# Altium schematic sheet 3_BANK1434conf.SchDoc(3_BANK1434conf)
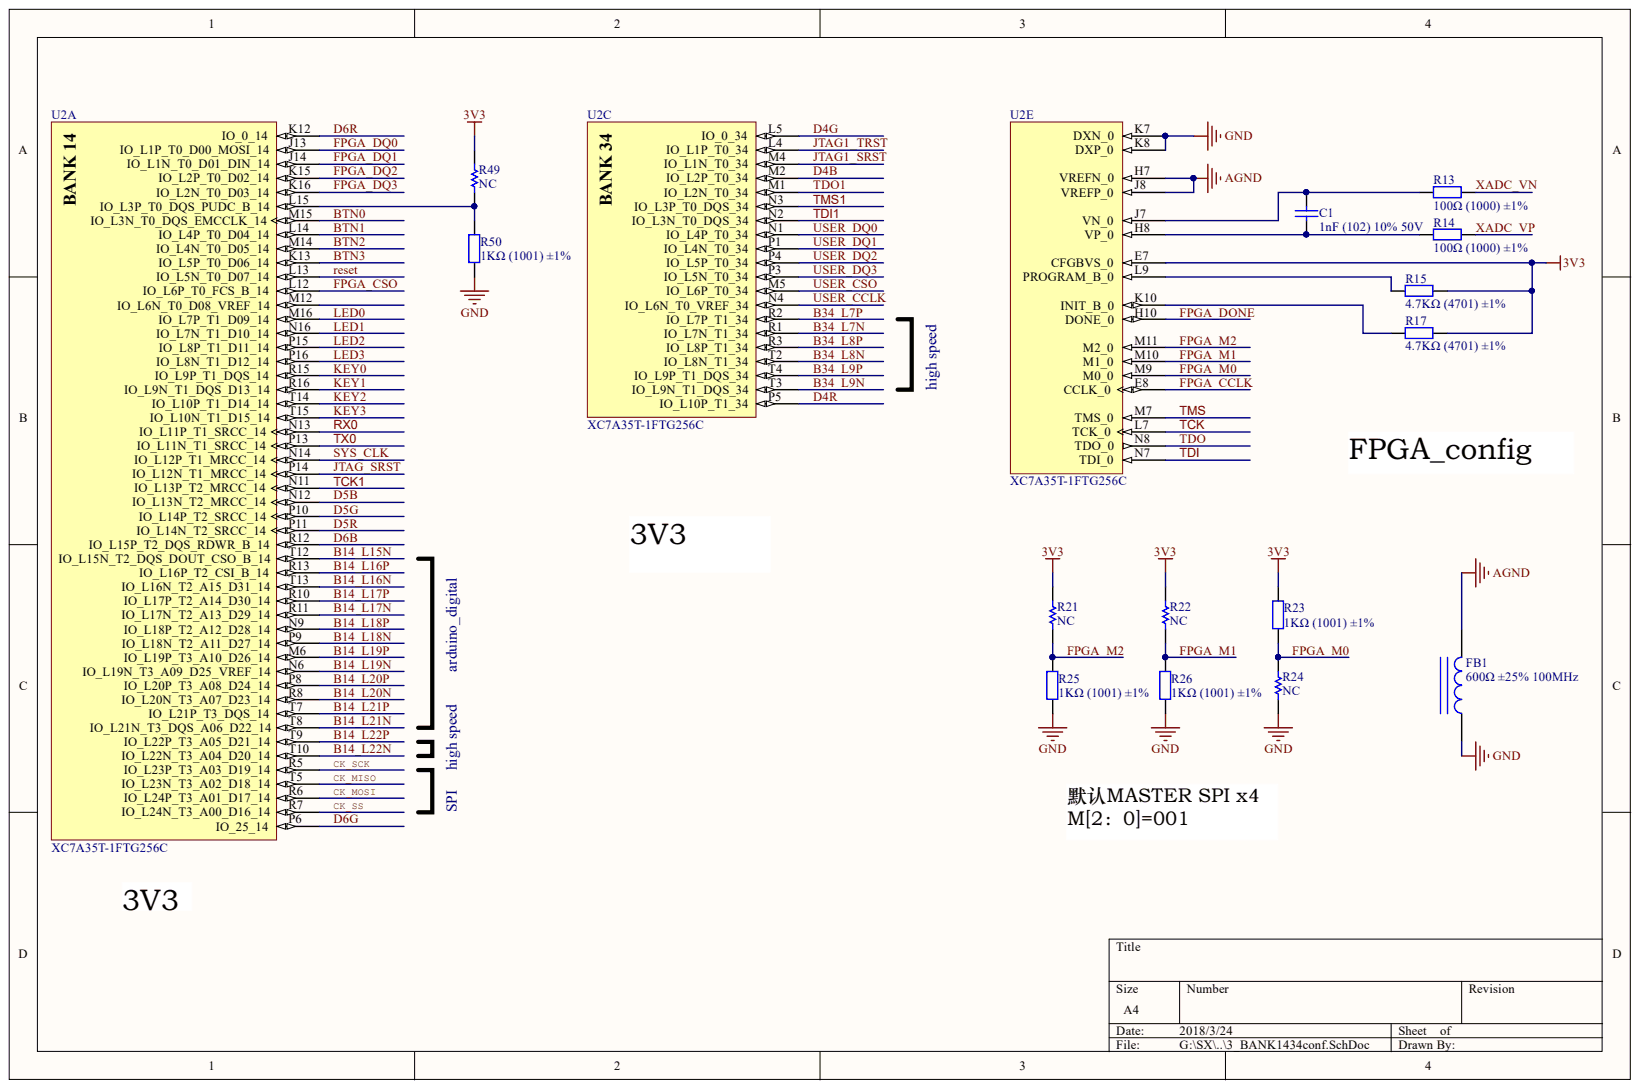
[diagram p0: 3_BANK1434conf.SchDoc]

## Parts
C1  1nF (102) 10% 50V  [C0402_BGA]
FB1  600Ω ±25% 100MHz  [0805_L]
R13  100Ω (1000) ±1%  [R0402_BGA]
R14  100Ω (1000) ±1%  [R0402_BGA]
R15  4.7KΩ (4701) ±1%  [R0402_BGA]
R17  4.7KΩ (4701) ±1%  [R0402_BGA]
R21  NC  [0402_R]
R22  NC  [0402_R]
R23  1KΩ (1001) ±1%  [0402_R]
R24  NC  [0402_R]
R25  1KΩ (1001) ±1%  [0402_R]
R26  1KΩ (1001) ±1%  [0402_R]
R49  NC  [0402_R]
R50  1KΩ (1001) ±1%  [0402_R]
U2  XC7A35T-1FTG256C  [FTG256]  (parts A,C,E)

## Nets
3V3: R15.1 R17.1 R21.2 R22.2 R23.2 R49.2 U2.E7(CFGBVS_0)
AGND: FB1.2 U2.H7(VREFN_0) U2.J8(VREFP_0)
GND: FB1.1 R24.1 R25.1 R26.1 R50.1 U2.K7(DXN_0) U2.K8(DXP_0)
B14_L15N: U2.T12(IO_L15N_T2_DQS_DOUT_CSO_B_14)
B14_L16N: U2.T13(IO_L16N_T2_A15_D31_14)
B14_L16P: U2.R13(IO_L16P_T2_CSI_B_14)
B14_L17N: U2.R11(IO_L17N_T2_A13_D29_14)
B14_L17P: U2.R10(IO_L17P_T2_A14_D30_14)
B14_L18N: U2.P9(IO_L18N_T2_A11_D27_14)
B14_L18P: U2.N9(IO_L18P_T2_A12_D28_14)
B14_L19N: U2.N6(IO_L19N_T3_A09_D25_VREF_14)
B14_L19P: U2.M6(IO_L19P_T3_A10_D26_14)
B14_L20N: U2.R8(IO_L20N_T3_A07_D23_14)
B14_L20P: U2.P8(IO_L20P_T3_A08_D24_14)
B14_L21N: U2.T8(IO_L21N_T3_DQS_A06_D22_14)
B14_L21P: U2.T7(IO_L21P_T3_DQS_14)
B14_L22N: U2.T10(IO_L22N_T3_A04_D20_14)
B14_L22P: U2.T9(IO_L22P_T3_A05_D21_14)
B34_L7N: U2.R1(IO_L7N_T1_34)
B34_L7P: U2.R2(IO_L7P_T1_34)
B34_L8N: U2.T2(IO_L8N_T1_34)
B34_L8P: U2.R3(IO_L8P_T1_34)
B34_L9N: U2.T3(IO_L9N_T1_DQS_34)
B34_L9P: U2.T4(IO_L9P_T1_DQS_34)
BTN0: U2.M15(IO_L3N_T0_DQS_EMCCLK_14)
BTN1: U2.L14(IO_L4P_T0_D04_14)
BTN2: U2.M14(IO_L4N_T0_D05_14)
BTN3: U2.K13(IO_L5P_T0_D06_14)
CK_MISO: U2.T5(IO_L23N_T3_A02_D18_14)
CK_MOSI: U2.R6(IO_L24P_T3_A01_D17_14)
CK_SCK: U2.R5(IO_L23P_T3_A03_D19_14)
CK_SS: U2.R7(IO_L24N_T3_A00_D16_14)
D4B: U2.M2(IO_L2P_T0_34)
D4G: U2.L5(IO_0_34)
D4R: U2.P5(IO_L10P_T1_34)
D5B: U2.N12(IO_L13N_T2_MRCC_14)
D5G: U2.P10(IO_L14P_T2_SRCC_14)
D5R: U2.P11(IO_L14N_T2_SRCC_14)
D6B: U2.R12(IO_L15P_T2_DQS_RDWR_B_14)
D6G: U2.P6(IO_25_14)
D6R: U2.K12(IO_0_14)
FPGA_CCLK: U2.E8(CCLK_0)
FPGA_CSO: U2.L12(IO_L6P_T0_FCS_B_14)
FPGA_DONE: U2.H10(DONE_0)
FPGA_DQ0: U2.J13(IO_L1P_T0_D00_MOSI_14)
FPGA_DQ1: U2.J14(IO_L1N_T0_D01_DIN_14)
FPGA_DQ2: U2.K15(IO_L2P_T0_D02_14)
FPGA_DQ3: U2.K16(IO_L2N_T0_D03_14)
FPGA_M0: R23.1 R24.2 U2.M9(M0_0)
FPGA_M1: R22.1 R26.2 U2.M10(M1_0)
FPGA_M2: R21.1 R25.2 U2.M11(M2_0)
JTAG1_SRST: U2.M4(IO_L1N_T0_34)
JTAG1_TRST: U2.L4(IO_L1P_T0_34)
JTAG_SRST: U2.P14(IO_L12N_T1_MRCC_14)
KEY0: U2.R15(IO_L9P_T1_DQS_14)
KEY1: U2.R16(IO_L9N_T1_DQS_D13_14)
KEY2: U2.T14(IO_L10P_T1_D14_14)
KEY3: U2.T15(IO_L10N_T1_D15_14)
LED0: U2.M16(IO_L7P_T1_D09_14)
LED1: U2.N16(IO_L7N_T1_D10_14)
LED2: U2.P15(IO_L8P_T1_D11_14)
LED3: U2.P16(IO_L8N_T1_D12_14)
RESET: U2.L13(IO_L5N_T0_D07_14)
RX0: U2.N13(IO_L11P_T1_SRCC_14)
SYS_CLK: U2.N14(IO_L12P_T1_MRCC_14)
TCK: U2.L7(TCK_0)
TCK1: U2.N11(IO_L13P_T2_MRCC_14)
TDI: U2.N7(TDI_0)
TDI1: U2.N2(IO_L3N_T0_DQS_34)
TDO: U2.N8(TDO_0)
TDO1: U2.M1(IO_L2N_T0_34)
TMS: U2.M7(TMS_0)
TMS1: U2.N3(IO_L3P_T0_DQS_34)
TX0: U2.P13(IO_L11N_T1_SRCC_14)
USER_CCLK: U2.N4(IO_L6N_T0_VREF_34)
USER_CSO: U2.M5(IO_L6P_T0_34)
USER_DQ0: U2.N1(IO_L4P_T0_34)
USER_DQ1: U2.P1(IO_L4N_T0_34)
USER_DQ2: U2.P4(IO_L5P_T0_34)
USER_DQ3: U2.P3(IO_L5N_T0_34)
XADC_VN: R13.2
XADC_VP: R14.2
NetC1_1: C1.1 R14.1 U2.H8(VP_0)
NetC1_2: C1.2 R13.1 U2.J7(VN_0)
NetR15_2: R15.2 U2.L9(PROGRAM_B_0)
NetR17_2: R17.2 U2.K10(INIT_B_0)
NetR49_1: R49.1 R50.2 U2.L15(IO_L3P_T0_DQS_PUDC_B_14)

Not connected: U2 M12(IO_L6N_T0_D08_VREF_14)

## Library data of the parts
C1 = 0402 1nF (102) 10% 50V: 贴片电容; Component Kind: Standard; ComponentLink1Description: 供应商链接; ComponentLink1URL: http://www.szlcsc.com/product/details_1875.html; Library Name: Miscellaneous Devices LC.IntLib; Package: 0402; Supplier: LC; SuppliersPartNumber: C1523
FB1 = 0805 600Ω 25% 100MHz: 贴片磁珠; Component Kind: Standard; ComponentLink1Description: 供应商链接; ComponentLink1URL: http://www.szlcsc.com/product/details_1369.html; Library Name: Miscellaneous Devices LC.IntLib; Package: 0805; Supplier: LC; SuppliersPartNumber: C1017
R13 R14 = 0402 100Ω (1000) 1%: 贴片电阻; Component Kind: Standard; ComponentLink1Description: 供应商链接; ComponentLink1URL: http://www.szlcsc.com/product/details_25819.html; Library Name: Miscellaneous Devices LC.IntLib; Package: 0402; Supplier: LC; SuppliersPartNumber: C25076
R15 R17 = 0402 4.7KΩ (4701) 1%: 贴片电阻; Component Kind: Standard; ComponentLink1Description: 供应商链接; ComponentLink1URL: http://www.szlcsc.com/product/details_26643.html; Library Name: Miscellaneous Devices LC.IntLib; Package: 0402; Supplier: LC; SuppliersPartNumber: C25900
R21 R22 R24 R49 = Res1: Resistor; Component Kind: Standard; LatestRevisionDate: 17-Jul-2002; LatestRevisionNote: Re-released for DXP Platform.; Library Name: Miscellaneous Devices.IntLib; PackageDescription: Axial Device, Thru-Hole; 2 Leads; 0.3 in Pin Spacing; PackageReference: AXIAL-0.3; Published: 8-Jun-2000; Simulation: RESISTOR; Value: NC; Publisher: Altium Limited
R23 R25 R26 R50 = 0402 1KΩ (1001) 1%: 贴片电阻; Component Kind: Standard; ComponentLink1Description: 供应商链接; ComponentLink1URL: http://www.szlcsc.com/product/details_12256.html; Library Name: Miscellaneous Devices LC.IntLib; Package: 0402; Supplier: LC; SuppliersPartNumber: C11702
U2 = XC7A35T-1FTG256C: Artix-7 FPGA, 170 User I/Os, 0 GTP, 256-Ball BGA, Speed Grade 1, Commercial Grade, Pb-Free; Manufacturer: Xilinx; Available User I/O: 170; Clock Mgmt Tiles (CMTs): 5; GTP: 0; Logic Cells: 33280; Speed Grades: 1; Total Block RAM: 1800 Kb; Component Kind: Standard; ComponentLink1Description: Manufacturer URL; ComponentLink1URL: http://www.xilinx.com/; ComponentLink2Description: Datasheet; ComponentLink2URL: http://www.xilinx.com/support/documentation/data_sheets/ds180_7Series_Overview.pdf; ComponentLink3Description: Package Specification; ComponentLink3URL: http://www.xilinx.com/support/documentation/user_guides/ug475_7Series_Pkg_Pinout.pdf; ComponentLink4Description: DC and Switching Characteristics; ComponentLink4URL: http://www.xilinx.com/support/documentation/data_sheets/ds181_Artix_7_Data_Sheet.pdf; ComponentLink5Description: Package File; ComponentLink5URL: http://www.xilinx.com/support/packagefiles/a7packages/xc7a200tsbg484pkg.txt; ComponentLink6Description: Packaging and Pinout; ComponentLink6URL: http://www.xilinx.com/support/documentation/user_guides/ug475_7Series_Pkg_Pinout.pdf; DatasheetVersion: v1.15; Library Name: Xilinx Artix-7.SchLib; Maximum Distributed RAM: 400 Kb; Mounting Technology: Surface Mount; NexusDeviceID: XC7A35T-1FTG256C; Operating Temperature Range: Commercial; PackageDescription: 256-Ball Wire-Bond Fine-Pitch Thin BGA, Body 17 x 17 mm, Pitch 1 mm; PackageReference: FTG-256; PackageVersion: Rev. 1.11; PartNumber: XC7A35T-1FTG256C
